# Altium schematic sheet PaddlePI_K210.SchDoc(PaddlePI_K210)
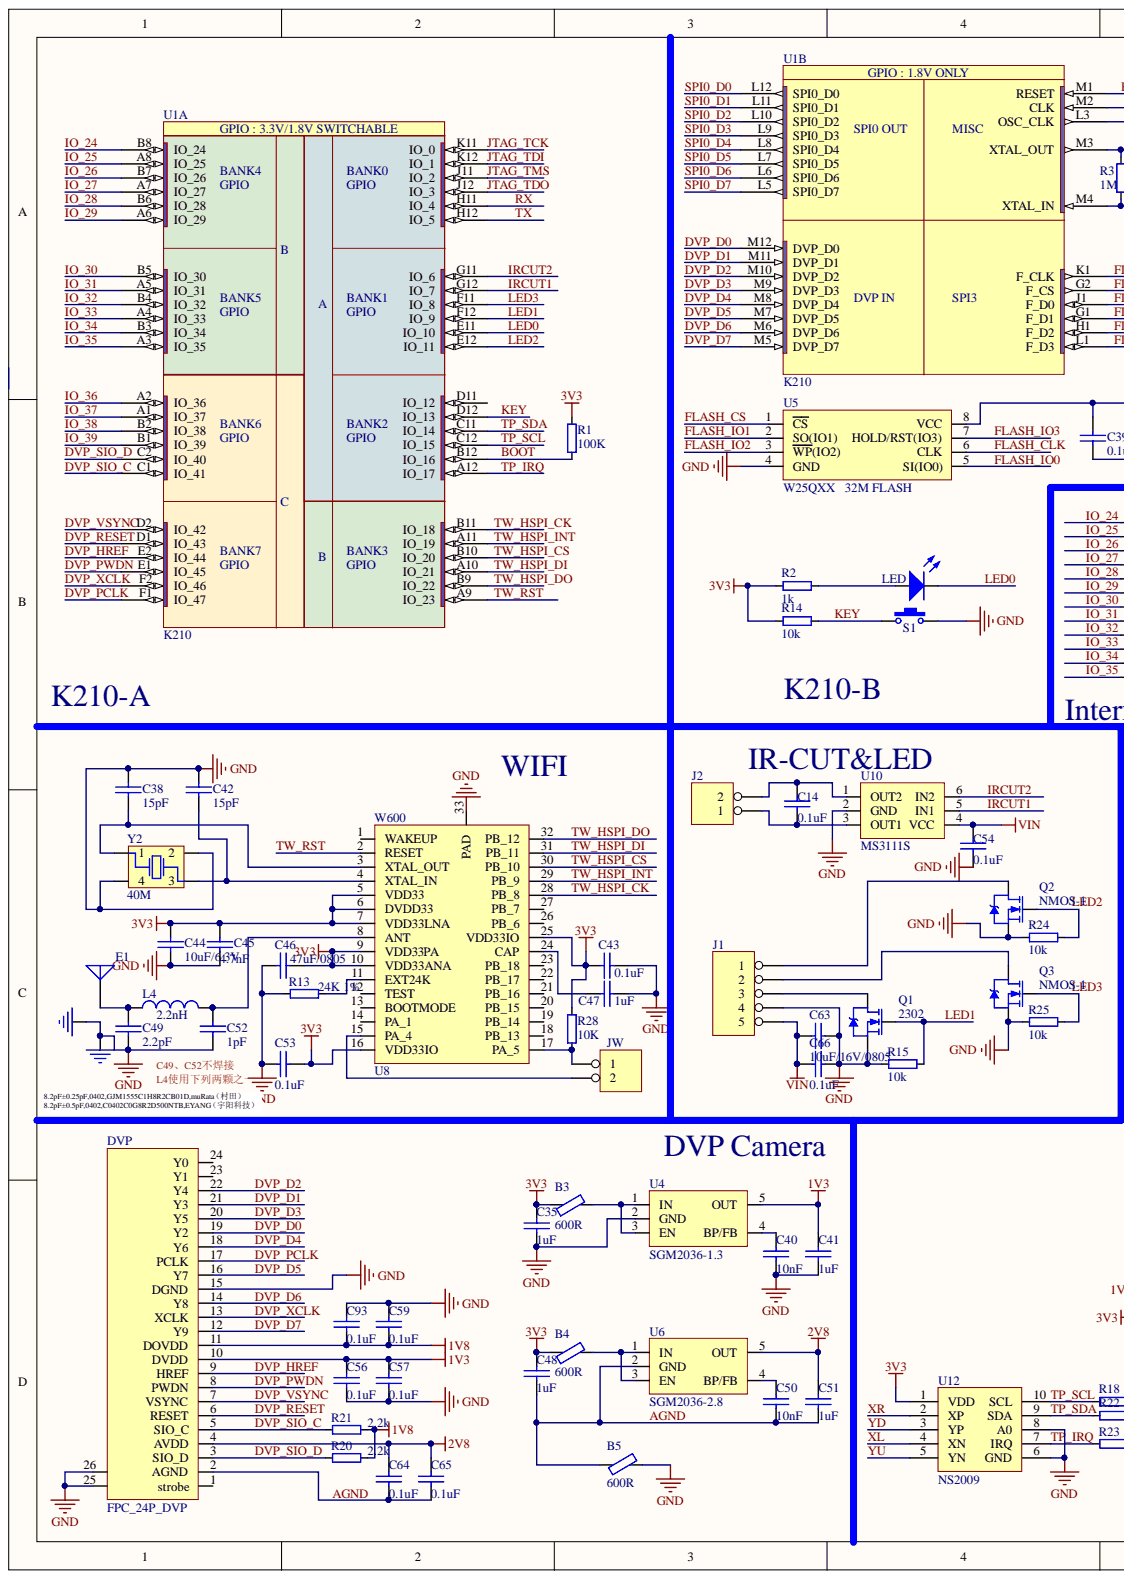
[diagram p0: PaddlePI_K210.SchDoc, left]
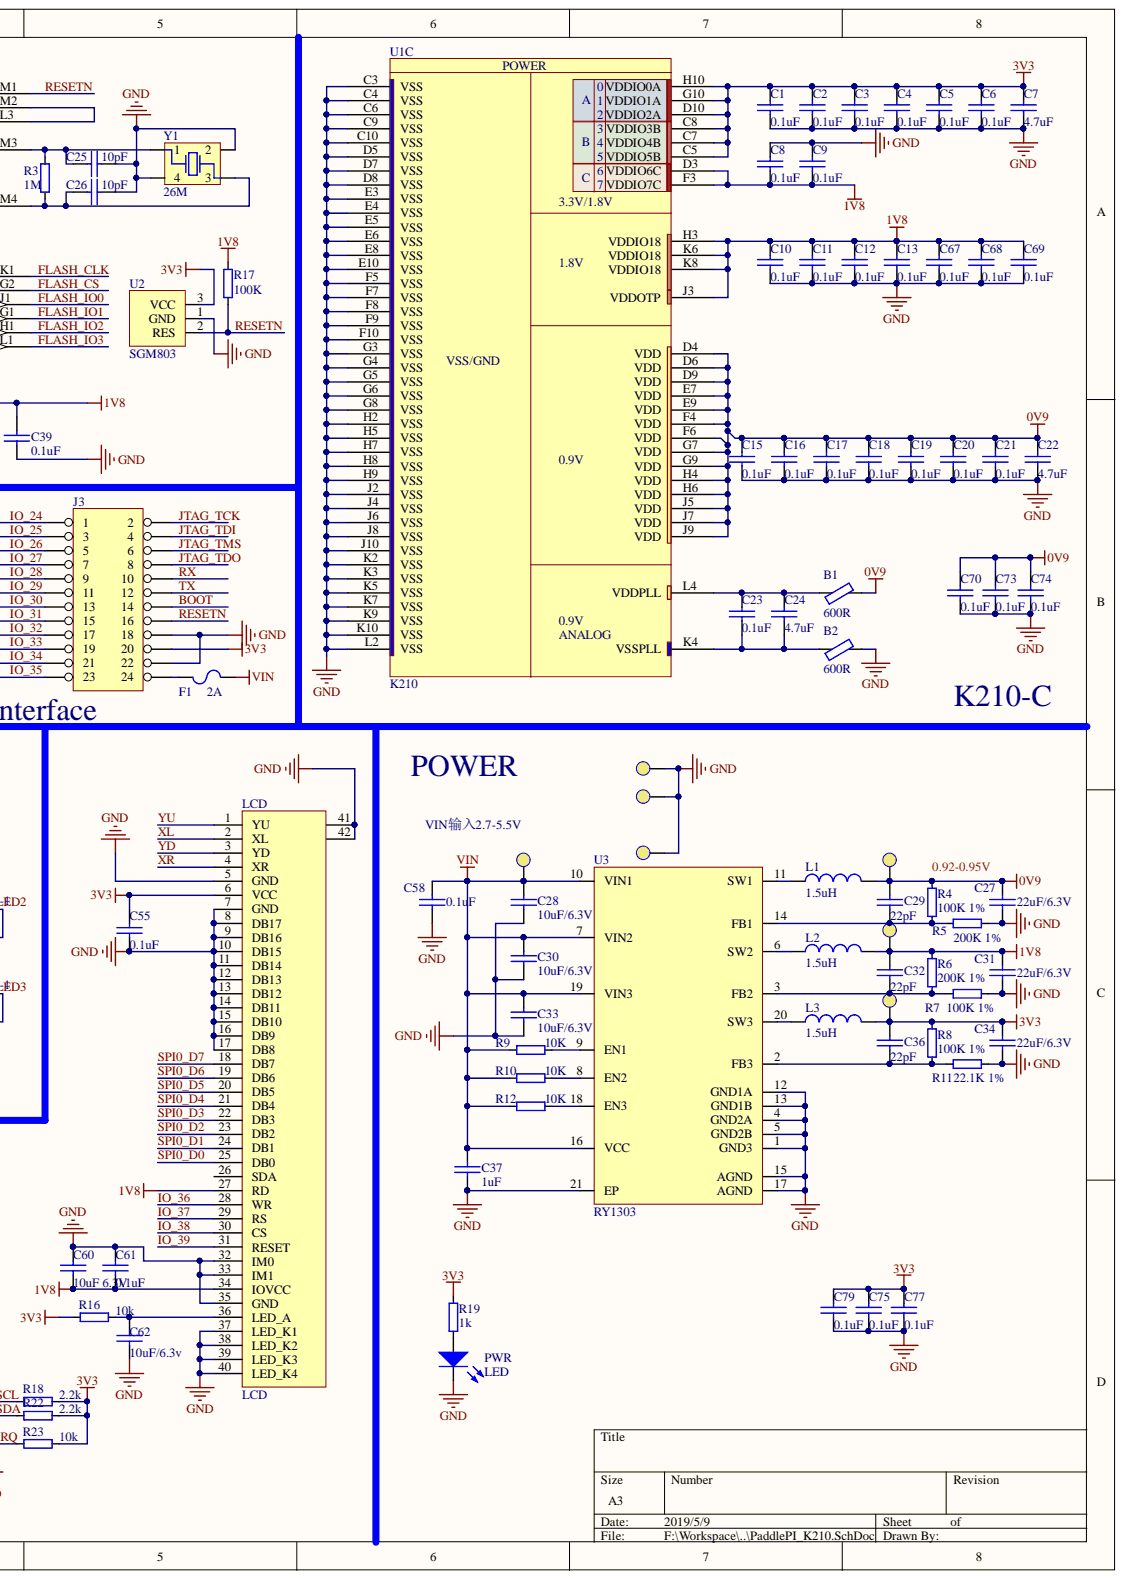
[diagram p1: PaddlePI_K210.SchDoc, right]

## Parts
0V9  TestPoint  [TestPoint]  (value not drawn)
1V8  TestPoint  [TestPoint]  (value not drawn)
3V3  TestPoint  [TestPoint]  (value not drawn)
5V  TestPoint  [TestPoint]  (value not drawn)
B1  600R  [0402_RES_SMT]
B2  600R  [0402_RES_SMT]
B3  600R  [0402_RES_SMT]
B4  600R  [0402_RES_SMT]
B5  600R  [0402_RES_SMT]
C1  0.1uF  [0402_CAP_SMT]
C2  0.1uF  [0402_CAP_SMT]
C3  0.1uF  [0402_CAP_SMT]
C4  0.1uF  [0402_CAP_SMT]
C5  0.1uF  [0402_CAP_SMT]
C6  0.1uF  [0402_CAP_SMT]
C7  4.7uF  [0402_CAP_SMT]
C8  0.1uF  [0402_CAP_SMT]
C9  0.1uF  [0402_CAP_SMT]
C10  0.1uF  [0402_CAP_SMT]
C11  0.1uF  [0402_CAP_SMT]
C12  0.1uF  [0402_CAP_SMT]
C13  0.1uF  [0402_CAP_SMT]
C14  0.1uF  [0402_CAP_SMT]
C15  0.1uF  [0402_CAP_SMT]
C16  0.1uF  [0402_CAP_SMT]
C17  0.1uF  [0402_CAP_SMT]
C18  0.1uF  [0402_CAP_SMT]
C19  0.1uF  [0402_CAP_SMT]
C20  0.1uF  [0402_CAP_SMT]
C21  0.1uF  [0402_CAP_SMT]
C22  4.7uF  [0402_CAP_SMT]
C23  0.1uF  [0402_CAP_SMT]
C24  4.7uF  [0402_CAP_SMT]
C25  10pF  [0402_CAP_SMT]
C26  10pF  [0402_CAP_SMT]
C27  22uF/6.3V  [0603_CAP_SMT]
C28  10uF/6.3V  [0805_CAP_SMT]
C29  22pF  [0402_CAP_SMT]
C30  10uF/6.3V  [0805_CAP_SMT]
C31  22uF/6.3V  [0603_CAP_SMT]
C32  22pF  [0402_CAP_SMT]
C33  10uF/6.3V  [0805_CAP_SMT]
C34  22uF/6.3V  [0603_CAP_SMT]
C35  1uF  [0402_CAP_SMT]
C36  22pF  [0402_CAP_SMT]
C37  1uF  [0402_CAP_SMT]
C38  15pF  [0402_CAP_SMT]
C39  0.1uF  [0402_CAP_SMT]
C40  10nF  [0402_CAP_SMT]
C41  1uF  [0402_CAP_SMT]
C42  15pF  [0402_CAP_SMT]
C43  0.1uF  [0402_CAP_SMT]
C44  10uF/6.3V  [0402_CAP_SMT]
C45  4.7nF  [0402_CAP_SMT]
C46  47uF/0805  [0805_CAP_SMT]
C47  1uF  [0402_CAP_SMT]
C48  1uF  [0402_CAP_SMT]
C49  2.2pF  [0402_CAP_SMT]
C50  10nF  [0402_CAP_SMT]
C51  1uF  [0402_CAP_SMT]
C52  1pF  [0402_CAP_SMT]
C53  0.1uF  [0402_CAP_SMT]
C54  0.1uF  [0402_CAP_SMT]
C55  0.1uF  [0402_CAP_SMT]
C56  0.1uF  [0402_CAP_SMT]
C57  0.1uF  [0402_CAP_SMT]
C58  0.1uF  [0603_CAP_SMT]
C59  0.1uF  [0402_CAP_SMT]
C60  10uF 6.3V  [0402_CAP_SMT]
C61  0.1uF  [0402_CAP_SMT]
C62  10uF/6.3v  [0402_CAP_SMT]
C63  10uF/16V/0805  [0805_CAP_SMT]
C64  0.1uF  [0402_CAP_SMT]
C65  0.1uF  [0402_CAP_SMT]
C66  0.1uF  [0402_CAP_SMT]
C67  0.1uF  [0402_CAP_SMT]
C68  0.1uF  [0402_CAP_SMT]
C69  0.1uF  [0402_CAP_SMT]
C70  0.1uF  [0402_CAP_SMT]
C73  0.1uF  [0402_CAP_SMT]
C74  0.1uF  [0402_CAP_SMT]
C75  0.1uF  [0402_CAP_SMT]
C77  0.1uF  [0402_CAP_SMT]
C79  0.1uF  [0402_CAP_SMT]
C93  0.1uF  [0402_CAP_SMT]
DVP  FPC_24P_DVP  [FPC0.5_SMT_24P_C]
E1  ANT-IPEX  [IPEX-3x3mm_2]  (value not drawn)
F1  2A  [1210_FUSE]
G1  TestPoint  [TestPoint - 2]  (value not drawn)
G2  TestPoint  [TestPoint]  (value not drawn)
G4  TestPoint  [TestPoint]  (value not drawn)
J1  (no value)  [5PIN_125MM_SMT_VERTICAL]
J2  HEADER 2  [2PIN_125MM_SMT_VERTICAL]  (value not drawn)
J3  HEADER 12X2  [12PIN_2H_N_2MM]  (value not drawn)
JW  HEADER 2  [2PIN_N_2MM]  (value not drawn)
L1  1.5uH  [PL0303-017A]
L2  1.5uH  [PL0303-017A]
L3  1.5uH  [PL0303-017A]
L4  2.2nH  [0402_RES_SMT]
LCD  LCD  [FPC0.5-SMT-40P-B]
LED  LED  [0603_LED_SMT]
PWR  LED  [0603_LED_SMT]
Q1  2302  [SOT-23]
Q2  NMOS-1  [SOT-23]
Q3  NMOS-1  [SOT-23]
R1  100K  [0402_RES_SMT]
R2  1k  [0402_RES_SMT]
R3  1M  [0402_RES_SMT]
R4  100K 1%  [0402_RES_SMT]
R5  200K 1%  [0402_RES_SMT]
R6  200K 1%  [0402_RES_SMT]
R7  100K 1%  [0402_RES_SMT]
R8  100K 1%  [0402_RES_SMT]
R9  10K  [0402_RES_SMT]
R10  10K  [0402_RES_SMT]
R11  22.1K 1%  [0402_RES_SMT]
R12  10K  [0402_RES_SMT]
R13  24K 1%  [0402_RES_SMT]
R14  10k  [0402_RES_SMT]
R15  10k  [0402_RES_SMT]
R16  10k  [0805_RES_SMT]
R17  100K  [0402_RES_SMT]
R18  2.2k  [0402_RES_SMT]
R19  1k  [0402_RES_SMT]
R20  2.2k  [0402_RES_SMT]
R21  2.2k  [0402_RES_SMT]
R22  2.2k  [0402_RES_SMT]
R23  10k  [0402_RES_SMT]
R24  10k  [0402_RES_SMT]
R25  10k  [0402_RES_SMT]
R28  10K  [0402_RES_SMT]
S1  SW-PB  [SW_4×8]  (value not drawn)
U1  K210  [BGA144C65P12X12_800X800X95]  (parts A,B,C)
U2  SGM803  [SOT-23]
U3  RY1303  [QFN20_3X3]
U4  SGM2036-1.3  [SOT23-5]
U5  W25QXX   32M FLASH  [WSON-8]
U6  SGM2036-2.8  [SOT23-5]
U8  W600  [QFN32_N]
U10  MS3111S  [SOT23-6]
U12  NS2009  [MSOP10]
Y1  26M  [X4-2520]
Y2  40M  [X4-2520]

## Nets
0V9: 0V9.TP B1.2 C15.1 C16.1 C17.1 C18.1 C19.1 C20.1 C21.1 C22.1 C27.1 C29.1 C70.1 C73.1 C74.1 L1.2 R4.2 U1.D4(VDD) U1.D6(VDD) U1.D9(VDD) U1.E7(VDD) U1.E9(VDD) U1.F4(VDD) U1.F6(VDD) U1.G7(VDD) U1.G9(VDD) U1.H4(VDD) U1.H6(VDD) U1.J5(VDD) U1.J7(VDD) U1.J9(VDD)
1V3: C41.1 C56.1 C57.1 DVP.10(DVDD) U4.5(OUT)
1V8: 1V8.TP C8.2 C9.2 C10.1 C11.1 C12.1 C13.1 C31.1 C32.1 C39.2 C59.2 C60.2 C61.2 C67.1 C68.1 C69.1 C93.2 DVP.11(DOVDD) L2.2 LCD.27(RD) LCD.34(IOVCC) R6.2 R17.2 R20.2 R21.2 U1.D3(6_VDDIO6C) U1.F3(7_VDDIO7C) U1.H3(VDDIO18) U1.J3(VDDOTP) U1.K6(VDDIO18) U1.K8(VDDIO18) U5.8(VCC)
2V8: C51.1 C64.1 C65.1 DVP.4(AVDD) U6.5(OUT)
3V3: 3V3.TP B3.1 B4.1 C1.1 C2.1 C3.1 C4.1 C5.1 C6.1 C7.1 C34.1 C35.1 C36.1 C43.2 C44.2 C45.2 C46.1 C48.1 C53.2 C55.1 C75.1 C77.1 C79.1 J3.20 L3.2 LCD.6(VCC) R1.2 R2.2 R8.2 R14.2 R16.1 R18.2 R19.2 R22.2 R23.2 R28.1 U1.C5(5_VDDIO5B) U1.C7(4_VDDIO4B) U1.C8(3_VDDIO3B) U1.D10(2_VDDIO2A) U1.G10(1_VDDIO1A) U1.H10(0_VDDIO0A) U2.3 U8.5(VDD33) U8.6(DVDD33) U8.7(VDD33LNA) U8.9(VDD33PA) U8.10(VDD33ANA) U8.16(VDD33IO) U8.25(VDD33IO) U12.1(VDD)
GND: B2.2 B5.1 C1.2 C2.2 C3.2 C4.2 C5.2 C6.2 C7.2 C8.1 C9.1 C10.2 C11.2 C12.2 C13.2 C15.2 C16.2 C17.2 C18.2 C19.2 C20.2 C21.2 C22.2 C25.1 C26.2 C27.2 C28.2 C30.2 C31.2 C33.2 C34.2 C35.2 C37.2 C38.1 C39.1 C40.2 C41.2 C42.1 C43.1 C44.1 C45.1 C46.2 C47.1 C49.1 C52.1 C53.1 C54.2 C55.2 C56.2 C57.2 C58.2 C59.1 C60.1 C61.1 C62.2 C63.2 C66.2 C67.2 C68.2 C69.2 C70.2 C73.2 C74.2 C75.2 C77.2 C79.2 C93.1 DVP.15(DGND) DVP.25 DVP.26 E1.2 E1.3 G1.TP G2.TP G4.TP J1.4 J3.18 J3.22 LCD.5(GND) LCD.7(GND) LCD.8(DB17) LCD.9(DB16) LCD.10(DB15) LCD.11(DB14) LCD.12(DB13) LCD.13(DB12) LCD.14(DB11) LCD.15(DB10) LCD.16(DB9) LCD.17(DB8) LCD.32(IM0) LCD.33(IM1) LCD.35(GND) LCD.37(LED_K1) LCD.38(LED_K2) LCD.39(LED_K3) LCD.40(LED_K4) LCD.41 LCD.42 PWR.2 Q1.2 Q2.2 Q3.2 R5.2 R7.2 R11.2 R13.2 R15.1 R24.1 R25.1 S1.2 U1.C3(VSS) U1.C4(VSS) U1.C6(VSS) U1.C9(VSS) U1.C10(VSS) U1.D5(VSS) U1.D7(VSS) U1.D8(VSS) U1.E3(VSS) U1.E4(VSS) U1.E5(VSS) U1.E6(VSS) U1.E8(VSS) U1.E10(VSS) U1.F5(VSS) U1.F7(VSS) U1.F8(VSS) U1.F9(VSS) U1.F10(VSS) U1.G3(VSS) U1.G4(VSS) U1.G5(VSS) U1.G6(VSS) U1.G8(VSS) U1.H2(VSS) U1.H5(VSS) U1.H7(VSS) U1.H8(VSS) U1.H9(VSS) U1.J2(VSS) U1.J4(VSS) U1.J6(VSS) U1.J8(VSS) U1.J10(VSS) U1.K2(VSS) U1.K3(VSS) U1.K5(VSS) U1.K7(VSS) U1.K9(VSS) U1.K10(VSS) U1.L2(VSS) U2.1 U3.1(GND3) U3.4(GND2A) U3.5(GND2B) U3.12(GND1A) U3.13(GND1B) U3.15(AGND) U3.17(AGND) U3.21(EP) U4.2(GND) U5.4(GND) U8.33(PAD) U10.2(GND) U12.6(GND) U12.8(A0) Y1.2 Y1.4 Y2.2 Y2.4
VIN: 5V.TP C28.1 C30.1 C33.1 C37.1 C54.1 C58.1 C63.1 C66.1 F1.1 J1.5 R9.1 R10.1 R12.1 U3.7(VIN2) U3.10(VIN1) U3.16(VCC) U3.19(VIN3) U10.4(VCC)
AGND: B5.2 C48.2 C50.2 C51.2 C64.2 C65.2 DVP.2(AGND) U6.2(GND)
BOOT: J3.14 R1.1 U1.B12(IO_16)
DVP_D0: DVP.19(Y2) U1.M12(DVP_D0)
DVP_D1: DVP.21(Y3) U1.M11(DVP_D1)
DVP_D2: DVP.22(Y4) U1.M10(DVP_D2)
DVP_D3: DVP.20(Y5) U1.M9(DVP_D3)
DVP_D4: DVP.18(Y6) U1.M8(DVP_D4)
DVP_D5: DVP.16(Y7) U1.M7(DVP_D5)
DVP_D6: DVP.14(Y8) U1.M6(DVP_D6)
DVP_D7: DVP.12(Y9) U1.M5(DVP_D7)
DVP_HREF: DVP.9(HREF) U1.E2(IO_44)
DVP_PCLK: DVP.17(PCLK) U1.F1(IO_47)
DVP_PWDN: DVP.8(PWDN) U1.E1(IO_45)
DVP_RESET: DVP.6(RESET) U1.D1(IO_43)
DVP_SIO_C: DVP.5(SIO_C) R21.1 U1.C1(IO_41)
DVP_SIO_D: DVP.3(SIO_D) R20.1 U1.C2(IO_40)
DVP_VSYNC: DVP.7(VSYNC) U1.D2(IO_42)
DVP_XCLK: DVP.13(XCLK) U1.F2(IO_46)
FLASH_CLK: U1.K1(F_CLK) U5.6(CLK)
FLASH_CS: U1.G2(F_CS) U5.1(CS)
FLASH_IO0: U1.J1(F_D0) U5.5(SI(IO0))
FLASH_IO1: U1.G1(F_D1) U5.2(SO(IO1))
FLASH_IO2: U1.H1(F_D2) U5.3(WP(IO2))
FLASH_IO3: U1.L1(F_D3) U5.7(HOLD/RST(IO3))
IO_24: J3.1 U1.B8(IO_24)
IO_25: J3.3 U1.A8(IO_25)
IO_26: J3.5 U1.B7(IO_26)
IO_27: J3.7 U1.A7(IO_27)
IO_28: J3.9 U1.B6(IO_28)
IO_29: J3.11 U1.A6(IO_29)
IO_30: J3.13 U1.B5(IO_30)
IO_31: J3.15 U1.A5(IO_31)
IO_32: J3.17 U1.B4(IO_32)
IO_33: J3.19 U1.A4(IO_33)
IO_34: J3.21 U1.B3(IO_34)
IO_35: J3.23 U1.A3(IO_35)
IO_36: LCD.28(WR) U1.A2(IO_36)
IO_37: LCD.29(RS) U1.A1(IO_37)
IO_38: LCD.30(CS) U1.B2(IO_38)
IO_39: LCD.31(RESET) U1.B1(IO_39)
IRCUT1: U1.G12(IO_7) U10.5(IN1)
IRCUT2: U1.G11(IO_6) U10.6(IN2)
JTAG_TCK: J3.2 U1.K11(IO_0)
JTAG_TDI: J3.4 U1.K12(IO_1)
JTAG_TDO: J3.8 U1.J12(IO_3)
JTAG_TMS: J3.6 U1.J11(IO_2)
KEY: R14.1 S1.1 U1.D12(IO_13)
LED0: LED.2 U1.E11(IO_10)
LED1: Q1.1 R15.2 U1.F12(IO_9)
LED2: Q2.1 R24.2 U1.E12(IO_11)
LED3: Q3.1 R25.2 U1.F11(IO_8)
RESETN: J3.16 R17.1 U1.M1(RESET) U2.2
RX: J3.10 U1.H11(IO_4)
SPI0_D0: LCD.25(DB0) U1.L12(SPI0_D0)
SPI0_D1: LCD.24(DB1) U1.L11(SPI0_D1)
SPI0_D2: LCD.23(DB2) U1.L10(SPI0_D2)
SPI0_D3: LCD.22(DB3) U1.L9(SPI0_D3)
SPI0_D4: LCD.21(DB4) U1.L8(SPI0_D4)
SPI0_D5: LCD.20(DB5) U1.L7(SPI0_D5)
SPI0_D6: LCD.19(DB6) U1.L6(SPI0_D6)
SPI0_D7: LCD.18(DB7) U1.L5(SPI0_D7)
TP_IRQ: R23.1 U1.A12(IO_17) U12.7(IRQ)
TP_SCL: R18.1 U1.C12(IO_15) U12.10(SCL)
TP_SDA: R22.1 U1.C11(IO_14) U12.9(SDA)
TW_HSPI_CK: U1.B11(IO_18) U8.28(PB_8)
TW_HSPI_CS: U1.B10(IO_20) U8.30(PB_10)
TW_HSPI_DI: U1.A10(IO_21) U8.31(PB_11)
TW_HSPI_DO: U1.B9(IO_22) U8.32(PB_12)
TW_HSPI_INT: U1.A11(IO_19) U8.29(PB_9)
TW_RST: U1.A9(IO_23) U8.2(RESET)
TX: J3.12 U1.H12(IO_5)
XL: LCD.2(XL) U12.4(XN)
XR: LCD.4(XR) U12.2(XP)
YD: LCD.3(YD) U12.3(YP)
YU: LCD.1(YU) U12.5(YN)
NetB1_1: B1.1 C23.1 C24.1 U1.L4(VDDPLL)
NetB2_1: B2.1 C23.2 C24.2 U1.K4(VSSPLL)
NetB3_2: B3.2 U4.1(IN) U4.3(EN)
NetB4_2: B4.2 U6.1(IN) U6.3(EN)
NetC14_1: C14.1 J2.2 U10.1(OUT2)
NetC14_2: C14.2 J2.1 U10.3(OUT1)
NetC25_2: C25.2 R3.2 U1.M3(XTAL_OUT) Y1.1
NetC26_1: C26.1 R3.1 U1.M4(XTAL_IN) Y1.3
NetC29_2: C29.2 R4.1 R5.1 U3.14(FB1)
NetC32_2: C32.2 R6.1 R7.1 U3.3(FB2)
NetC36_2: C36.2 R8.1 R11.1 U3.2(FB3)
NetC38_2: C38.2 U8.3(XTAL_OUT) Y2.1
NetC40_1: C40.1 U4.4(BP/FB)
NetC42_2: C42.2 U8.4(XTAL_IN) Y2.3
NetC47_2: C47.2 U8.24(CAP)
NetC49_2: C49.2 E1.1 L4.1
NetC50_1: C50.1 U6.4(BP/FB)
NetC52_2: C52.2 L4.2 U8.8(ANT)
NetC62_1: C62.1 LCD.36(LED_A) R16.2
NetF1_2: F1.2 J3.24
NetJ1_1: J1.1 Q2.3
NetJ1_2: J1.2 Q3.3
NetJ1_3: J1.3 Q1.3
NetJW_1: JW.1 R28.2 U8.17(PA_5)
NetJW_2: JW.2 U8.15(PA_4)
NetL1_1: L1.1 U3.11(SW1)
NetL2_1: L2.1 U3.6(SW2)
NetL3_1: L3.1 U3.20(SW3)
NetLED_1: LED.1 R2.1
NetPWR_1: PWR.1 R19.1
NetR9_2: R9.2 U3.9(EN1)
NetR10_2: R10.2 U3.8(EN2)
NetR12_2: R12.2 U3.18(EN3)
NetR13_1: R13.1 U8.11(EXT24K)
NetU1_L3: U1.L3(OSC_CLK) U1.M2(CLK)
N00058:

Not connected: DVP 1(strobe) 23(Y1) 24(Y0); LCD 26(SDA); U1 D11(IO_12); U8 1(WAKEUP) 12(TEST) 13(BOOTMODE) 14(PA_1) 18(PB_13) 19(PB_14) 20(PB_15) 21(PB_16) 22(PB_17) 23(PB_18) 26(PB_6) 27(PB_7)

## Library data of the parts
B1-B5 = BEAD: Library Name: ZX_MyLib.SchLib; Component Kind: Standard
C1-C70 C73-C75 C77 C79 C93 = CAP: Capacitor; Library Name: ZX_MyLib.SchLib; Component Kind: Standard
F1 = Fuse: Library Name: ZX_MyLib.SchLib; Description: Fuse; Component Kind: Standard
J1 = HEADER 5: Library Name: ZX_MyLib.SchLib; Component Kind: Standard
L1-L4 = Inductor: Value: XXuH; Code_IEC: 1005; Published: 8-Jun-2000; PackageReference: 0402-A; PackageDescription: Chip Inductor; Library Name: ZX_MyLib.SchLib; LatestRevisionNote: IPC-7351 Footprint Added.; LatestRevisionDate: 29-May-2009; Description: Inductor; Component Kind: Standard; Code_JEITA: 0402; Publisher: Altium Limited
LCD = LCD_JLT28030A: Library Name: ZX_MyLib.SchLib; Component Kind: Standard
LED PWR = LED: Typical RED GaAs LED; Published: 8-Jun-2000; PackageReference: LED-1; Library Name: ZX_MyLib.SchLib; LatestRevisionNote: Mech Layer 1 removed.; LatestRevisionDate: 08-Jul-2005; Component Kind: Standard; Publisher: Altium Limited
Q1-Q3 = NMOS-1: SOT封装; Note: Pin 2 is electrically connected to pin 4.; Published: 8-Jun-2000; PackageReference: TO-220AB; Library Name: ZX_MyLib.SchLib; LatestRevisionNote: Re-released for DXP Platform.; LatestRevisionDate: 17-Jul-2002; DatasheetVersion: PD-9.814; ComponentLink1URL: http://www.irf.com/; ComponentLink1Description: Manufacturer Link; Component Kind: Standard; Code_JEDEC: TO-220-AB; Publisher: Altium Limited
R1-R25 R28 = RES: Library Name: ZX_MyLib.SchLib; Component Kind: Standard
S1 = SW-PB: Switch; Published: 24-Mar-1999; PackageReference: SPST-2; Library Name: ZX_MyLib.SchLib; LatestRevisionNote: Re-released for DXP Platform.; LatestRevisionDate: 17-Jul-2002; Component Kind: Standard; Publisher: Altium Limited
U1 = K210_1: Canaan Kendryte K210 AI SoC; VENDOR: CANAAN INC.; Library Name: ZX_MyLib.SchLib; Component Kind: Standard
U4 U6 = SGM2036: Library Name: ZX_MyLib.SchLib; Component Kind: Standard
U5 = W25QXX: W25QXX  32M FLASH; Library Name: ZX_MyLib.SchLib; Component Kind: Standard
U10 = SY6712ABC: Library Name: ZX_MyLib.SchLib; Component Kind: Standard
Y1 Y2 = CRYSTAL2: Library Name: ZX_MyLib.SchLib; Component Kind: Standard
0V9 1V8 3V3 5V G1 G2 G4 = TestPoint: Library Name: ZX_MyLib.SchLib; Component Kind: Standard
DVP = FPC_24P_DVP: Library Name: ZX_MyLib.SchLib; Component Kind: Standard
E1 = ANT-IPEX: Library Name: ZX_MyLib.SchLib; Component Kind: Standard
J2 JW = HEADER 2: Library Name: ZX_MyLib.SchLib; Component Kind: Standard
J3 = HEADER 12X2: Library Name: ZX_MyLib.SchLib; Component Kind: Standard
U2 = SGM803: Library Name: ZX_MyLib.SchLib; Component Kind: Standard
U3 = RY1303: Library Name: ZX_MyLib.SchLib; Component Kind: Standard
U8 = W600: Library Name: ZX_MyLib.SchLib; Component Kind: Standard
U12 = NS2009: Component Kind: Standard; Library Name: IronMan.IntLib
